# Altium schematic sheet POWER SUPPLY.SchDoc
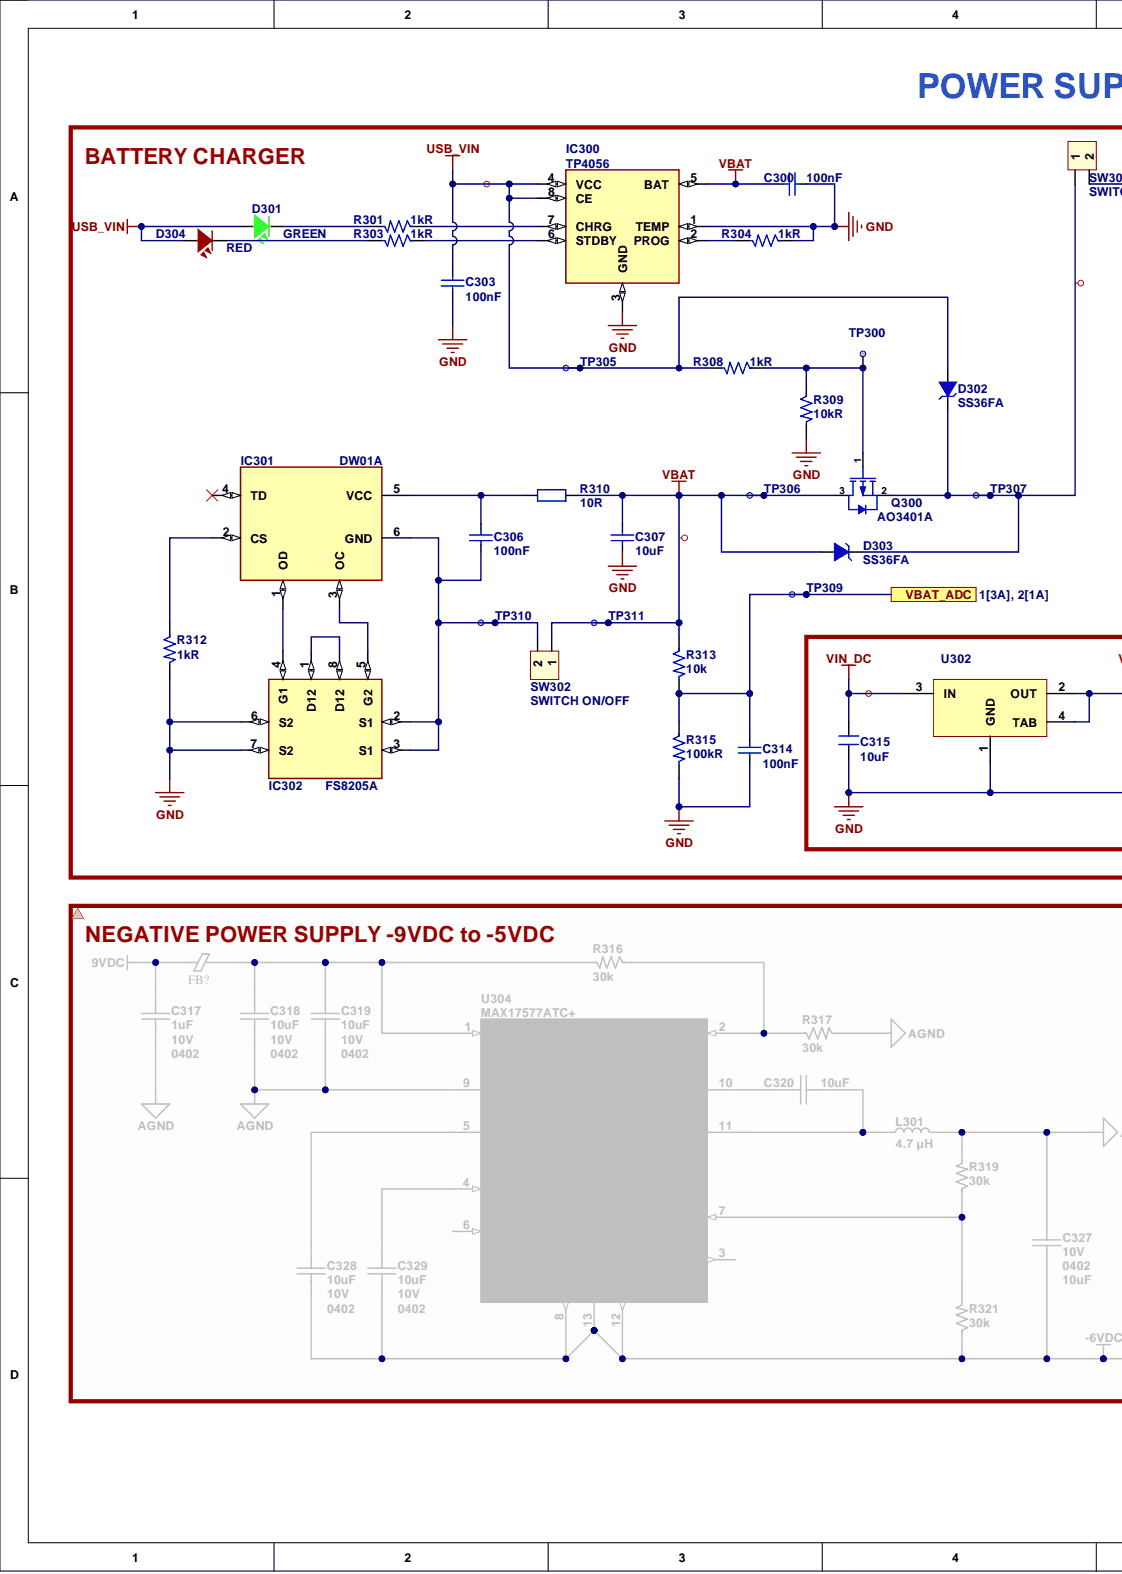
[diagram p0: POWER SUPPLY.SchDoc, left]
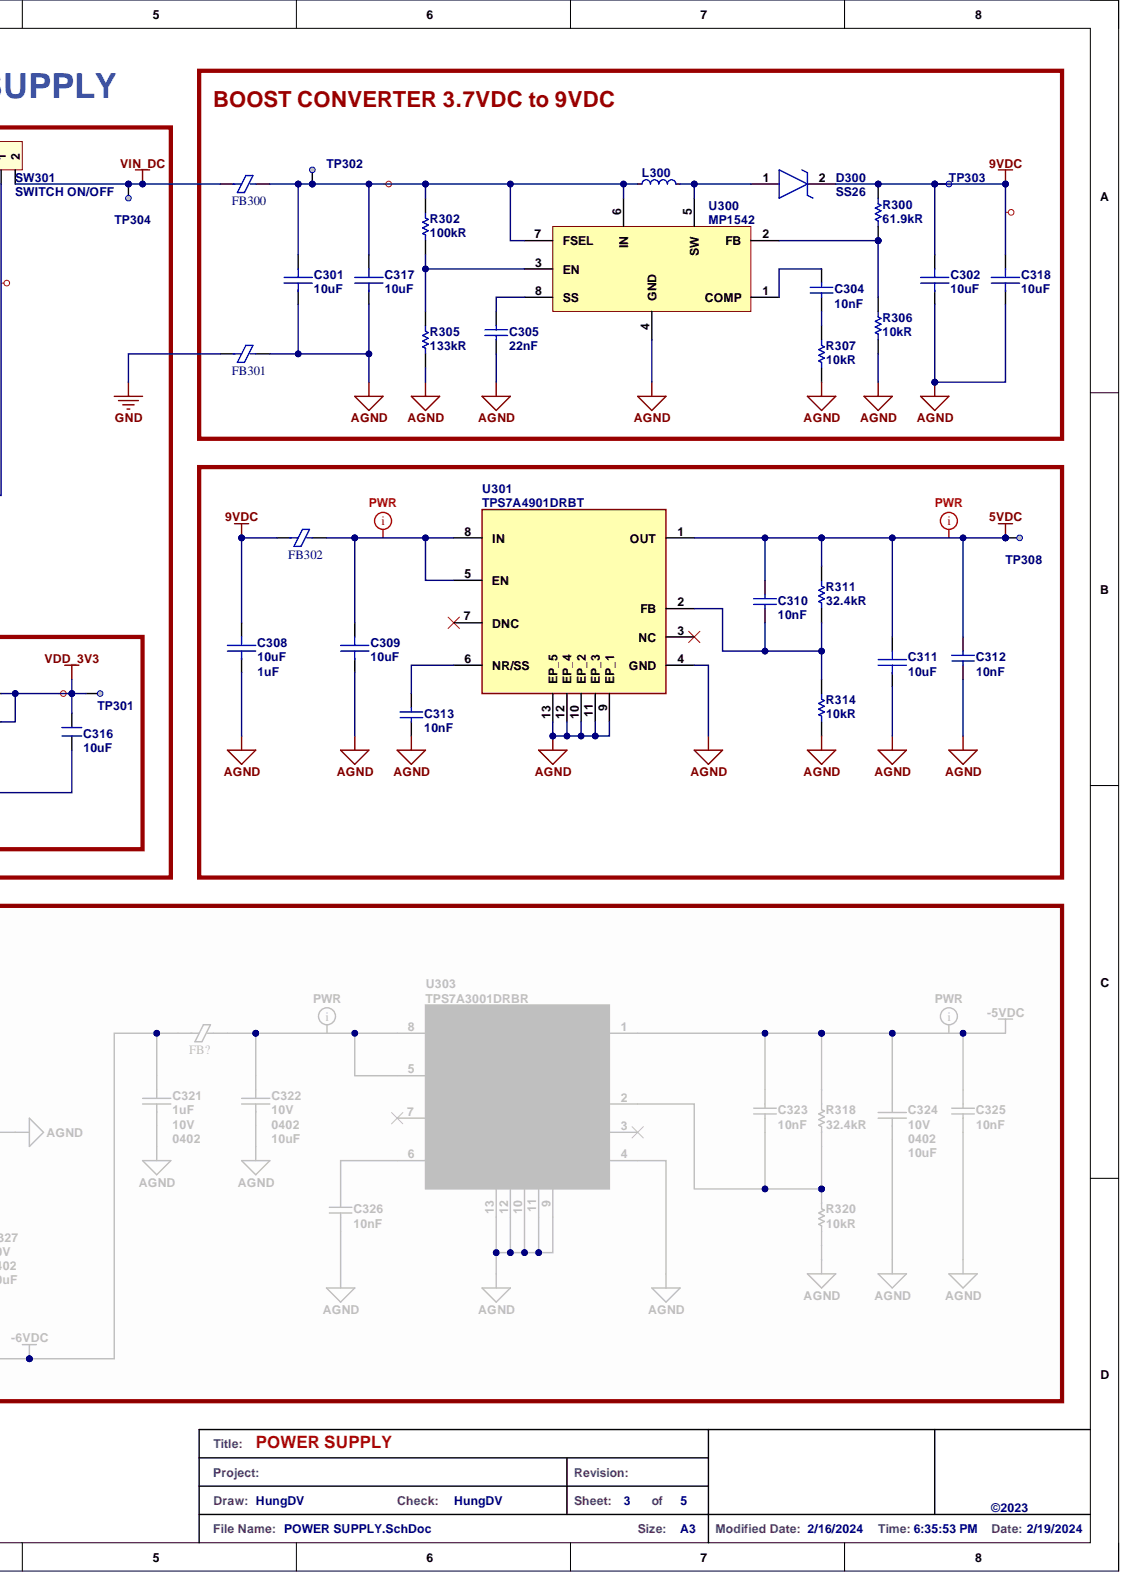
[diagram p1: POWER SUPPLY.SchDoc, right]

## Parts
C300  100nF  [CAP0603-M]
C301  10uF  [C0805]
C302  10uF  [C0805]
C303  100nF  [CAP0603-M]
C304  10nF  [CAP0603-M]
C305  22nF  [CAP0603-M]
C306  100nF  [CAP0603-M]
C307  10uF  [CAP0603-M]
C308  10uF  Capacitance: 1uF  [CAP0603-M]
C309  10uF  [CAP0603-M]
C310  Capacitance: 10nF  [CAP0603-M]  (hidden comment: 10uF)
C311  10uF  [CAP0603-M]
C312  10uF  Capacitance: 10nF  [CAP0603-M]
C313  10uF  Capacitance: 10nF  [CAP0603-M]
C314  100nF  [CAP0603-M]
C315  10uF  [C_0603]
C316  10uF  [C_0603]
C317  10uF  [C0805]
C318  10uF  [C0805]
D300  SS26  [SOD123F]
D301  GREEN  [LED RIGHT]
D302  SS36FA  [SOD123F]
D303  SS36FA  [SOD123F]
D304  RED  [LED RIGHT]
FB300  FerriteBead 220Ohms @100MHz 0201  [INDC1608X80N]  (value not drawn)
FB301  FerriteBead 220Ohms @100MHz 0201  [INDC1608X80N]  (value not drawn)
FB302  FerriteBead 220Ohms @100MHz 0201  [INDC1608X80N]  (value not drawn)
IC300  TP4056  [TP4056]
IC301  DW01A  [SOT23-6]
IC302  FS8205A  [FS8205A]
L300  FIXED IND 4.7UH 1.1A 288MOHM SMD  [IND25X25CZ]  (value not drawn)
Q300  AO3401A  [SOT23-3]
R300  61.9kR  [R_0603]
R301  1kR  [R_0603]
R302  100kR  [R_0603]
R303  1kR  [R_0603]
R304  1kR  [R_0603]
R305  133kR  [R_0603]
R306  10kR  [R_0603]
R307  10kR  [R_0603]
R308  1kR  [R_0603]
R309  10kR  [R_0603]
R310  10R  [R_0603]
R311  32.4kR  [R_0603]
R312  1kR  [R_0603]
R313  10k  [R_0603]
R314  10kR  [R_0603]
R315  100kR  [R_0603]
SW301  SWITCH ON/OFF  [XH2.54]
SW302  SWITCH ON/OFF  [XH2.54]
TP300  SIREN_EN  [TP_1mm]  (value not drawn)  (no BOM)
TP301  3V3  [TP_1mm]  (value not drawn)  (no BOM)
TP302  VIN  [TP_1mm]  (value not drawn)  (no BOM)
TP303  9VDC  [TP_1mm]  (value not drawn)  (no BOM)
TP304  SIREN_EN  [TP_1mm]  (value not drawn)  (no BOM)
TP305  SIREN_EN  [TP_1mm]  (value not drawn)  (no BOM)
TP306  VBAT  [TP_1mm]  (value not drawn)  (no BOM)
TP307  SIREN_EN  [TP_1mm]  (value not drawn)  (no BOM)
TP308  5V  [TP_1mm]  (no BOM)
TP309  SIREN_EN  [TP_1mm]  (value not drawn)  (no BOM)
TP310  SIREN_EN  [TP_1mm]  (value not drawn)  (no BOM)
TP311  SIREN_EN  [TP_1mm]  (value not drawn)  (no BOM)
U300  MP1542  [TSOP65P490X95-8N]
U301  TPS7A4901DRBT  [IC_DRV8601DRBT]
U302  LM1117-3.3  [SOT223-4]  (value not drawn)

## Ports
VBAT_ADC

## Nets
5VDC: C310.2 C311.2 C312.2 R311.2 TP308.1 U301.1(OUT)
9VDC: C302.2 C308.2 C318.2 D300.2 FB302.1 R300.2 TP303.1
AGND: C301.1 C302.1 C305.1 C308.1 C309.1 C311.1 C312.1 C313.1 C317.1 C318.1 FB301.2 R305.1 R306.1 R307.1 R314.1 U300.4(GND) U301.4(GND) U301.9(EP_1) U301.10(EP_2) U301.11(EP_3) U301.12(EP_4) U301.13(EP_5)
GND: C300.1 C303.2 C307.1 C314.1 C315.1 C316.1 FB301.1 IC300.1(TEMP) IC300.3(GND) IC302.6(S2) IC302.7(S2) R304.1 R309.2 R312.2 R315.2 U302.1(GND)
USB_VIN: C303.1 D301.1 D302.1 D304.1 IC300.4(VCC) IC300.8(CE) R308.2 TP305.1
VBAT: C300.2 C307.2 D303.1 IC300.5(BAT) Q300.3 R310.2 R313.1 SW302.1 TP306.1 TP311.1
VDD_3V3: C316.2 TP301.1 U302.2(OUT) U302.4(TAB)
VIN_DC: C315.2 FB300.1 SW301.2 TP304.1 U302.3(IN)
VBAT_ADC: C314.2 R313.2 R315.1 TP309.1 ; port VBAT_ADC
NetC301_2: C301.2 C317.2 FB300.2 L300.1 R302.2 TP302.1 U300.6(IN) U300.7(FSEL)
NetC304_1: C304.1 R307.2
NetC304_2: C304.2 U300.1(COMP)
NetC305_2: C305.2 U300.8(SS)
NetC306_1: C306.1 IC301.6(GND) IC302.2(S1) IC302.3(S1) SW302.2 TP310.1
NetC306_2: C306.2 IC301.5(VCC) R310.1
NetC309_2: C309.2 FB302.2 U301.5(EN) U301.8(IN)
NetC310_1: C310.1 R311.1 R314.2 U301.2(FB)
NetC313_2: C313.2 U301.6(NR/SS)
NetD300_1: D300.1 L300.2 U300.5(SW)
NetD301_2: D301.2 R301.2
NetD302_2: D302.2 D303.2 Q300.2 SW301.1 TP307.1
NetD304_2: D304.2 R303.2
NetIC300_2: IC300.2(PROG) R304.2
NetIC300_6: IC300.6(STDBY) R303.1
NetIC300_7: IC300.7(CHRG) R301.1
NetIC301_1: IC301.1(OD) IC302.4(G1)
NetIC301_2: IC301.2(CS) R312.1
NetIC301_3: IC301.3(OC) IC302.5(G2)
NetIC302_1: IC302.1(D12) IC302.8(D12)
NetQ300_1: Q300.1 R308.1 R309.1 TP300.1
NetR300_1: R300.1 R306.2 U300.2(FB)
NetR302_1: R302.1 R305.2 U300.3(EN)

Not connected: IC301 4(TD); U301 3(NC) 7(DNC)

## Library data of the parts
C300 C303 C306 C314 = CapSMD: CAP CER 100nF 16V X5R 0603; Component Kind: Standard; Library Name: My Library.SchLib
C301 C302 C317 C318 = CAP_10uF_0402: CAP CER 10UF 16V X5R 0805; Manufacturer 1: Samsung; Manufacturer Part Number 1: CL05A106MP8NUB8; Capacitance: 10uF; Component Kind: Standard; Library Name: Library.SCHLIB; Manufacturer 2: Murata; Manufacturer Part Number 2: GRM155R61A106ME11D; Revision: 2
C304 = CAP_10nF_0402: Component Kind: Standard; Library Name: Library.SCHLIB
C305 = CAP_10nF_0402: CAP CER  22nF 50V C0G/NP0 0603; Component Kind: Standard; Library Name: Library.SCHLIB
C307 = CapSMD: CAP CER 10UF 16V X5R 0603; Component Kind: Standard; Library Name: My Library.SchLib
C308 C309 C311 = CAP_10uF_0402: CAP CER 10UF 16V X5R 0603; Manufacturer 1: Samsung; Manufacturer Part Number 1: CL05A106MP8NUB8; Component Kind: Standard; Manufacturer 2: Murata; Manufacturer Part Number 2: GRM155R61A106ME11D; Revision: 2
  C308: Library Name: Library.SCHLIB
  C309 C311: Capacitance: 10uF; Library Name: EV_Mainboard.SCHLIB
C310 C312 C313 = CAP_10nF_0201: CAP CER 10UF 16V X5R 0603; Manufacturer 1: Taiyo Yuden; Manufacturer Part Number 1: TMK063BJ103KP-F; Component Kind: Standard; Library Name: EV_Mainboard.SCHLIB; Manufacturer 2: Murata; Manufacturer Part Number 2: GRM033R61A103KA01D
C315 C316 = S1200-000091: CAP CER 10UF 16V X5R 0603; Manufacturer: MURATA; Database Table Name: S1200_Capacitor$; Component Kind: Standard; Library Name: Selex_Component_Lib.SVNDbLib
D300 = DF2S6.8MFS,L3M: TVS Diode (Uni-directional); Manufacturer_Name: SEMTECH; Component Kind: Standard; Library Name: Simulation Generic Components.IntLib
D301 = LED_1: LED GREEN; Component Kind: Standard; Library Name: RTLS_Anchor.SCHLIB
D302 D303 = DIODE_ZEN CBB: DIODE ZENER 15V 410MW SOD123; Component Kind: Standard; Library Name: Library.SCHLIB
D304 = LED_3: LED RED; Component Kind: Standard; Library Name: RTLS_Anchor.SCHLIB
FB300-FB302 = FerriteBead_220Ohm_0402: 220 Ohms @ 100 MHz 1 Power Line Ferrite Bead 0402 (1005 Metric) 1.5A 95mOhm; Manufacturer 1: TDK; Manufacturer Part Number 1: MPZ1005S221HT000; Component Kind: Standard; Library Name: Library.SCHLIB; Manufacturer 2: Murata; Manufacturer Part Number 2: BLM15PX221SN1D
IC300 = TP4056: Complete single cell Li-Ion battery with a constant current / constant voltage linear charger; Component Kind: Standard; Library Name: My Library.SchLib
IC301 = DW01A: One Cell Lithium-ion/Polymer Battery Protection IC; Component Kind: Standard; Library Name: My Library.SchLib
L300 = DFE201610E-4R7M=P2: 4.7 µH Shielded Wirewound Inductor 1.1 A 288mOhm Max 0806 (2016 Metric); Manufacturer 1: Murata; Component Kind: Standard; Library Name: Library.SCHLIB; Manufacturer Part Number 1: DFE201610E-4R7M=P2
Q300 = P-MOSFET_TP1_CBB: MOSFET P-CH 30V 4A SOT23-3L; Component Kind: Standard; Library Name: Library.SCHLIB
R300 = RES_100K_0201: RES SMD 61.9k OHM 1% 1/8W 0603; Manufacturer 1: Yageo; Manufacturer Part Number 1: RC0201FR-07100KL; Component Kind: Standard; Library Name: Library.SCHLIB; Manufacturer 2: Panasonic Electronic Components; Manufacturer Part Number 2: ERJ-1GNF1003C; Revision: 1
R301 R303 R304 R308 R312 = R: RES SMD 1K OHM 1% 1/8W 0603; Manufacturer: Yageo; Component Kind: Standard; Library Name: Anh_Trung.SchLib
R302 = RES_100K_0201: RES SMD 100K OHM 1% 1/8W 0603; Manufacturer 1: Yageo; Manufacturer Part Number 1: RC0201FR-07100KL; Component Kind: Standard; Library Name: Library.SCHLIB; Manufacturer 2: Panasonic Electronic Components; Manufacturer Part Number 2: ERJ-1GNF1003C; Revision: 1
R305 = RES_100K_0201: RES SMD 133K OHM 1% 1/8W 0603; Manufacturer 1: Yageo; Manufacturer Part Number 1: RC0201FR-07100KL; Component Kind: Standard; Library Name: Library.SCHLIB; Manufacturer 2: Panasonic Electronic Components; Manufacturer Part Number 2: ERJ-1GNF1003C; Revision: 1
R306 R307 = RES_100K_0201: RES SMD 10K OHM 1% 1/8W 0603; Manufacturer 1: Yageo; Manufacturer Part Number 1: RC0201FR-07100KL; Component Kind: Standard; Library Name: Library.SCHLIB; Manufacturer 2: Panasonic Electronic Components; Manufacturer Part Number 2: ERJ-1GNF1003C; Revision: 1
R309 = R: RES SMD 10K OHM 1% 1/8W 0603; Manufacturer: Yageo; Component Kind: Standard; Library Name: Anh_Trung.SchLib
R310 = Resistor - SMD: RES SMD 10R OHM 1% 1/8W 0603; Manufacturer: Generic Components; Component Kind: Standard; Library Name: My Library.SchLib
R311 = RES_10K_0201: RES SMD 32.4k OHM 1% 1/8W 0603; Manufacturer 1: Yageo; Manufacturer Part Number 1: RC0201FR-0711K3L; Component Kind: Standard; Library Name: X8.1.1_PCB_MAIN.SCHLIB; Manufacturer 2: Panasonic Electronic Components; Revision: 1
R313 = R: RES SMD 10K OHM 1% 1/8W 0805; Manufacturer: Yageo; Component Kind: Standard; Library Name: Anh_Trung.SchLib
R314 = RES_10K_0201: RES SMD 10K OHM 1% 1/8W 0603; Manufacturer 1: Yageo; Manufacturer Part Number 1: RC0201FR-0710KL; Component Kind: Standard; Library Name: X8.1.1_PCB_MAIN.SCHLIB; Manufacturer 2: Panasonic Electronic Components; Manufacturer Part Number 2: ERJ-1GEF1002C; Revision: 1
R315 = R: RES SMD 100K OHM 1% 1/8W 0603; Manufacturer: Yageo; Component Kind: Standard; Library Name: Anh_Trung.SchLib
SW301 SW302 = Con2: Connector 2; Component Kind: Standard; Library Name: Anh_Trung.SchLib
TP300-TP311 = S1400-000002: Test_Point_1mm; Database Table Name: S1400_Test_Point$; Library Name: Selex_Component_Lib.SVNDbLib
U301 = TPS7A4901DRBT: IC REG LINEAR POS ADJ 150MA 8SON; Component Kind: Standard; Library Name: Library.SCHLIB; Manufacturer Part Number 1: IC REG LINEAR POS ADJ 150MA 8SON
U302 = S1001-000002: IC REG LINEAR 3.3V 1A SOT223; Manufacturer: DIODE; Database Table Name: S1001_Power_Managerment_IC$; Component Kind: Standard; Manufacturer Part Number 1: LM1117-3.3; Library Name: Selex_Component_Lib.SVNDbLib
IC302 = FS8205A: Component Kind: Standard; Library Name: My Library.SchLib
U300 = MP1542: Component Kind: Standard; Library Name: Library.SCHLIB; Manufacturer Part Number 1: MP1542
